# Altium schematic sheet Display-Port.SchDoc(Display-Port)
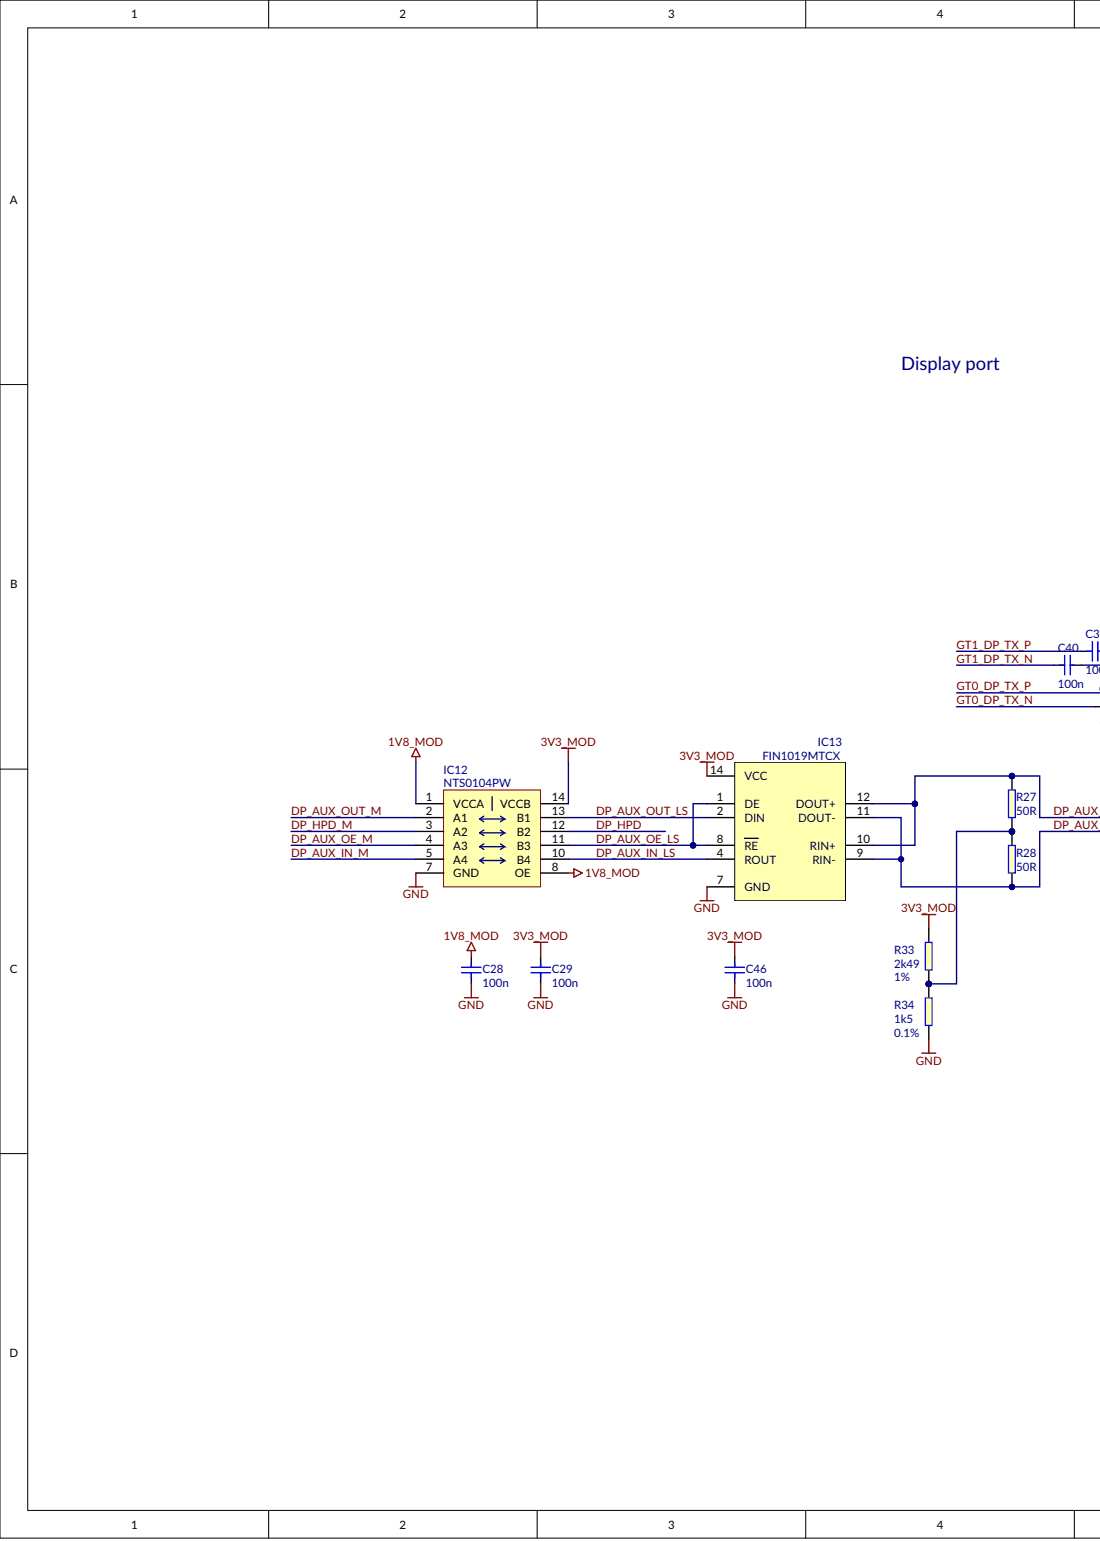
[diagram p0: Display-Port.SchDoc, left]
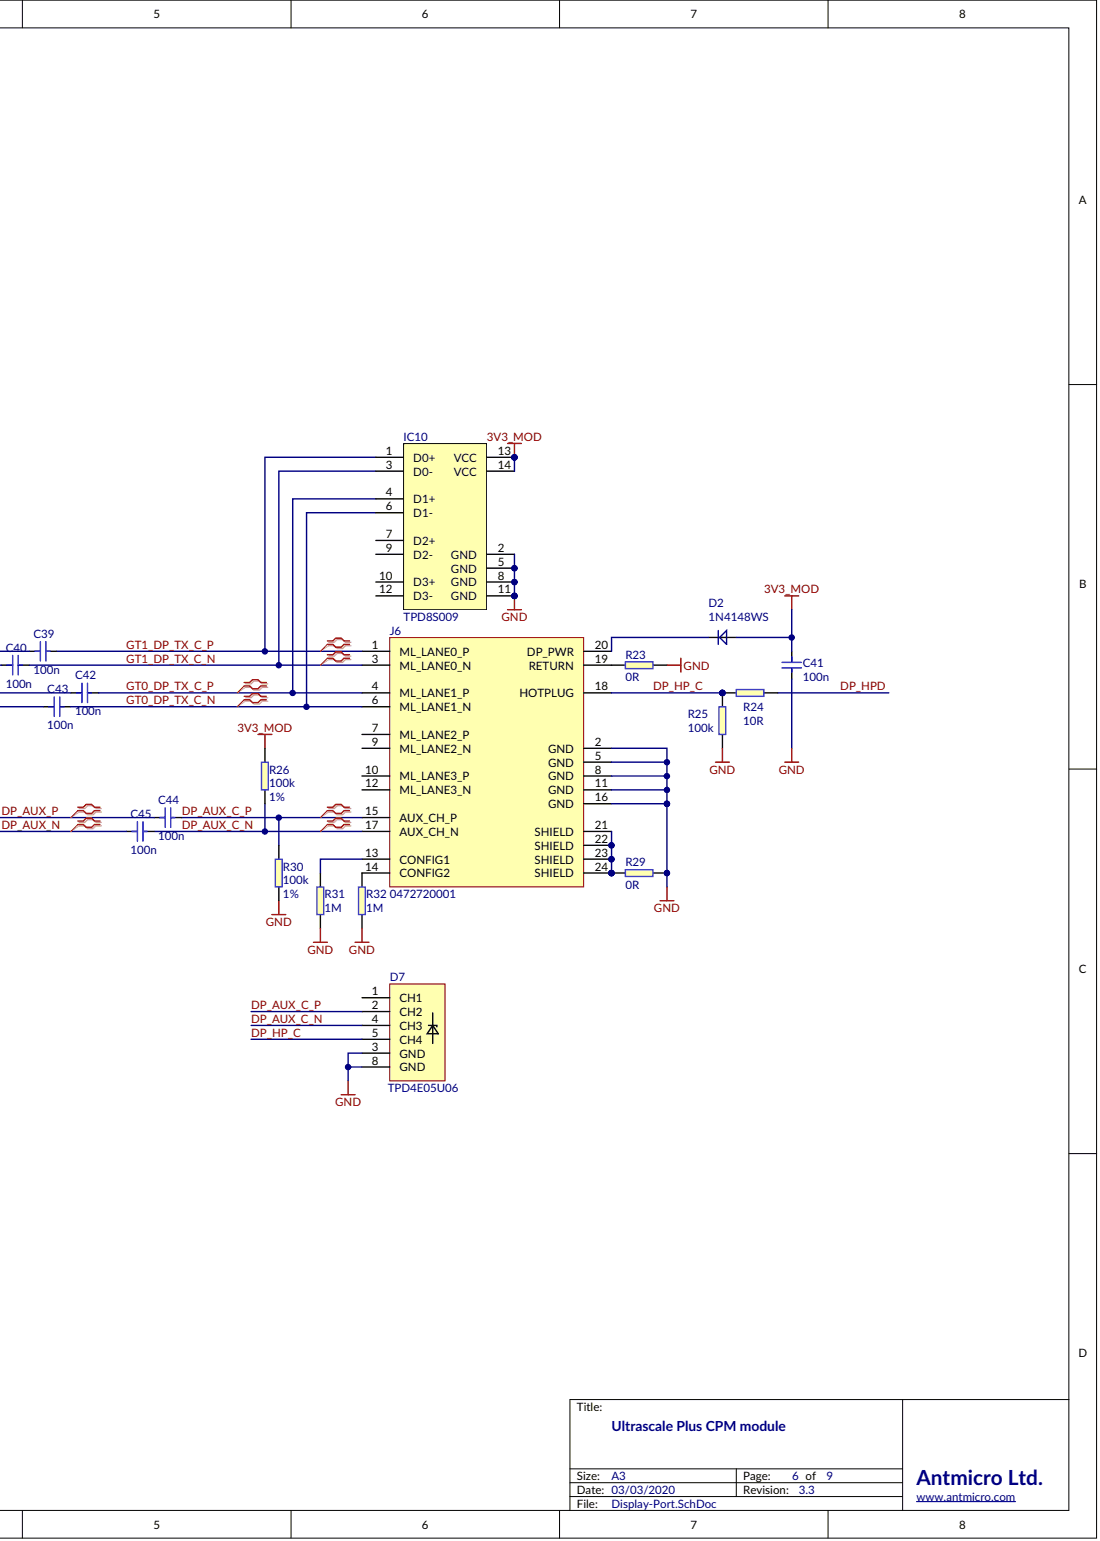
[diagram p1: Display-Port.SchDoc, right]

## Parts
C28  100n
C29  100n
C39  100n
C40  100n
C41  100n
C42  100n
C43  100n
C44  100n
C45  100n
C46  100n
D2  1N4148WS
D7  TPD4E05U06
IC10  TPD8S009
IC12  NTS0104PW
IC13  FIN1019MTCX
J6  0472720001
R23  0R
R24  10R
R25  100k
R26  Tolerance: 1%  (hidden comment: 100k)
R27  50R
R28  50R
R29  0R
R30  Tolerance: 1%  (hidden comment: 100k)
R31  1M
R32  1M
R33  Tolerance: 1%  (hidden comment: 2k49)
R34  Tolerance: 0.1%  (hidden comment: 1k5)

## Nets
1V8_MOD: C28.2 IC12.1(VCCA) IC12.8(OE)
3V3_MOD: C29.2 C41.1 C46.2 D2.A IC10.13(VCC) IC10.14(VCC) IC12.14(VCCB) IC13.14(VCC) R26.2 R33.2
GND: C28.1 C29.1 C41.2 C46.1 D7.3(GND) D7.8(GND) IC10.2(GND) IC10.5(GND) IC10.8(GND) IC10.11(GND) IC12.7(GND) IC13.7(GND) J6.2(GND) J6.5(GND) J6.8(GND) J6.11(GND) J6.16(GND) R23.2 R25.1 R29.2 R30.1 R31.1 R32.1 R34.1
DP_AUX_C_N: C45.2 D7.4(CH3) J6.17(AUX_CH_N) R26.1
DP_AUX_C_P: C44.2 D7.2(CH2) J6.15(AUX_CH_P) R30.2
DP_AUX_IN_LS: IC12.10(B4) IC13.4(ROUT)
DP_AUX_IN_M: IC12.5(A4)
DP_AUX_N: C45.1 IC13.9(RIN-) IC13.11(DOUT-) R28.1
DP_AUX_OE_LS: IC12.11(B3) IC13.1(DE) IC13.8(RE)
DP_AUX_OE_M: IC12.4(A3)
DP_AUX_OUT_LS: IC12.13(B1) IC13.2(DIN)
DP_AUX_OUT_M: IC12.2(A1)
DP_AUX_P: C44.1 IC13.10(RIN+) IC13.12(DOUT+) R27.2
DP_HP_C: D7.5(CH4) J6.18(HOTPLUG) R24.1 R25.2
DP_HPD: IC12.12(B2) R24.2
DP_HPD_M: IC12.3(A2)
GT0_DP_TX_C_N: C43.2 IC10.6(D1-) J6.6(ML_LANE1_N)
GT0_DP_TX_C_P: C42.2 IC10.4(D1+) J6.4(ML_LANE1_P)
GT0_DP_TX_N: C43.1
GT0_DP_TX_P: C42.1
GT1_DP_TX_C_N: C40.2 IC10.3(D0-) J6.3(ML_LANE0_N)
GT1_DP_TX_C_P: C39.2 IC10.1(D0+) J6.1(ML_LANE0_P)
GT1_DP_TX_N: C40.1
GT1_DP_TX_P: C39.1
NetD2_C: D2.C J6.20(DP_PWR)
NetJ6_13: J6.13(CONFIG1) R31.2
NetJ6_14: J6.14(CONFIG2) R32.2
NetJ6_19: J6.19(RETURN) R23.1
NetJ6_21: J6.21(SHIELD) J6.22(SHIELD) J6.23(SHIELD) J6.24(SHIELD) R29.1
NetR27_1: R27.1 R28.2 R33.1 R34.2

Not connected: D7 1(CH1); IC10 7(D2+) 9(D2-) 10(D3+) 12(D3-); J6 7(ML_LANE2_P) 9(ML_LANE2_N) 10(ML_LANE3_P) 12(ML_LANE3_N)

## Library data of the parts
C28 C29 C39-C46 = (no symbol name): Part Number: C_100n_0603; Manufacturer: WALSIN; Part Symbol: 0603B104K500CT; Value: 100n; Supplier 1: Farnell; Supplier Part Number 1: 2496834; ClassName: DisplayPort
D2 = (no symbol name): Manufacturer: MULTICOMP; Part Number: 1N4148WS; Value: 1N4148WS; Supplier 1: Farnell; Supplier Part Number 1: 1466524; Part Symbol: 1N4148WS
D7 = (no symbol name): Part Number: TPD4E05U06_PASS; Manufacturer: Texas Instruments; Part Symbol: TPD4E05U06DQAR; Value: TPD4E05U06; Supplier 1: Digi-Key; Supplier Part Number 1: 296-35765-1-ND
IC10 = (no symbol name): Manufacturer: TEXAS INSTRUMENTS; Part Symbol: TPD8S009DSMR; Part Number: TPD8S009; Value: TPD8S009; Supplier 1: Farnell; Supplier Part Number 1: 1772003; Supplier 2: Mouser; Supplier Part Number 2: 595-TPD8S009DSMR; Supplier 3: Digi-Key; Supplier Part Number 3: 296-23829-1-ND; ClassName: DisplayPort
IC12 = (no symbol name): Manufacturer: NXP; Part Number: NTS0104PW; Value: NTS0104PW; Supplier 1: Farnell; Supplier Part Number 1: 2164815; Supplier 2: Mouser; Supplier Part Number 2: 771-NTS0104PW118; Part Symbol: NTS0104PW; ClassName: DisplayPort
IC13 = (no symbol name): Manufacturer: OM SEMICONDUCTOR; Part Number: FIN1019MTCX; Value: FIN1019MTCX; Supplier 1: Mouser; Supplier Part Number 1: 512-FIN1019MX; Supplier 2: Digi-Key; Supplier Part Number 2: FIN1019MXCT-ND; Part Symbol: FIN1019MTCX; ClassName: DisplayPort
J6 = (no symbol name): Manufacturer: Molex; ClassName: DisplayPort; Part Symbol: 0472720001; Supplier 1: Digi-Key; Supplier Part Number 1: WM19271CT-ND; Value: 0472720001
R23 R29 = (no symbol name): Part Number: R_0R_0603; Manufacturer: BOURNS; Part Symbol: CR0603-J/-000ELF; Tolerance: 5%; Value: 0R; Supplier 1: Farnell; Supplier Part Number 1: 2008343; ClassName: DisplayPort
R24 = (no symbol name): Part Number: R_10R_0603; Manufacturer: MULTICOMP; Part Symbol: MCWR06X10R0FTL; Value: 10R; Supplier 1: Farnell; Supplier Part Number 1: 2447233; ClassName: DisplayPort; Supplier 2: Farnell; Supplier Part Number 2: 2447233
R25 = (no symbol name): Part Number: R_100k_0603; Manufacturer: BOURNS; Part Symbol: CR0603-JW-104GLF; Tolerance: 5%; Value: 100k; Supplier 1: Farnell; Supplier Part Number 1: 2008366; ClassName: DisplayPort
R26 R30 = (no symbol name): Part Number: R_100k_0603_1%; Manufacturer: BOURNS; Part Symbol: CR0603-FX-1003HLF; Value: 100k; Supplier 1: Farnell; Supplier Part Number 1: 2333553
R27 R28 = (no symbol name): Part Number: R_50R_0603_1%; Manufacturer: VISHAY; Part Symbol: CRCW060350R0FKEA; Tolerance: 1%; Value: 50R; Supplier 1: Farnell; Supplier Part Number 1: 2112878; ClassName: DisplayPort
R31 R32 = (no symbol name): Part Number: R_1M_0603; Manufacturer: BOURNS; Part Symbol: CR0603-JW-105GLF; Value: 1M; Supplier 1: Farnell; Supplier Part Number 1: 2008367; ClassName: DisplayPort
R33 = (no symbol name): Part Number: R_2k49_0603_1%; Manufacturer: Vishay; Part Symbol: CRCW06032K49FKEA; Value: 2k49; Supplier 1: Farnell; Supplier Part Number 1: 1469767
R34 = (no symbol name): Part Number: R_1k5_0603; Manufacturer: TE CONNECTIVITY / NEOHM; Part Symbol: CPF0603B1K5E1; Value: 1k5; Supplier 1: Farnell; Supplier Part Number 1: 1697378; ClassName: DisplayPort
